# Altium schematic sheet GroveMIDIInTRS.SchDoc
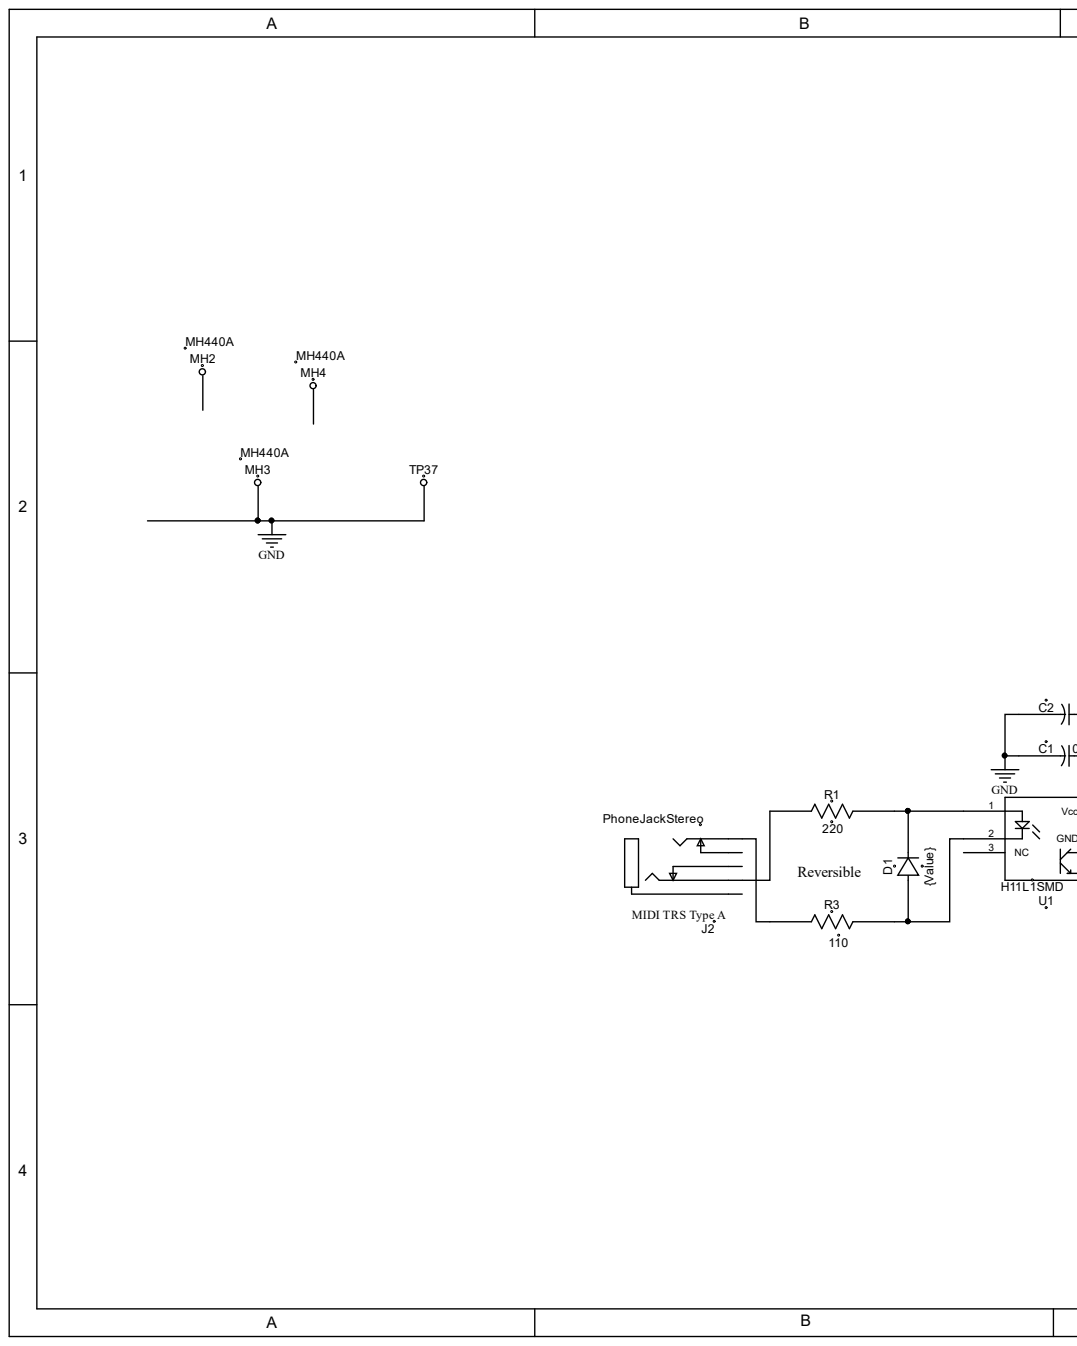
[diagram p0: GroveMIDIInTRS.SchDoc, left]
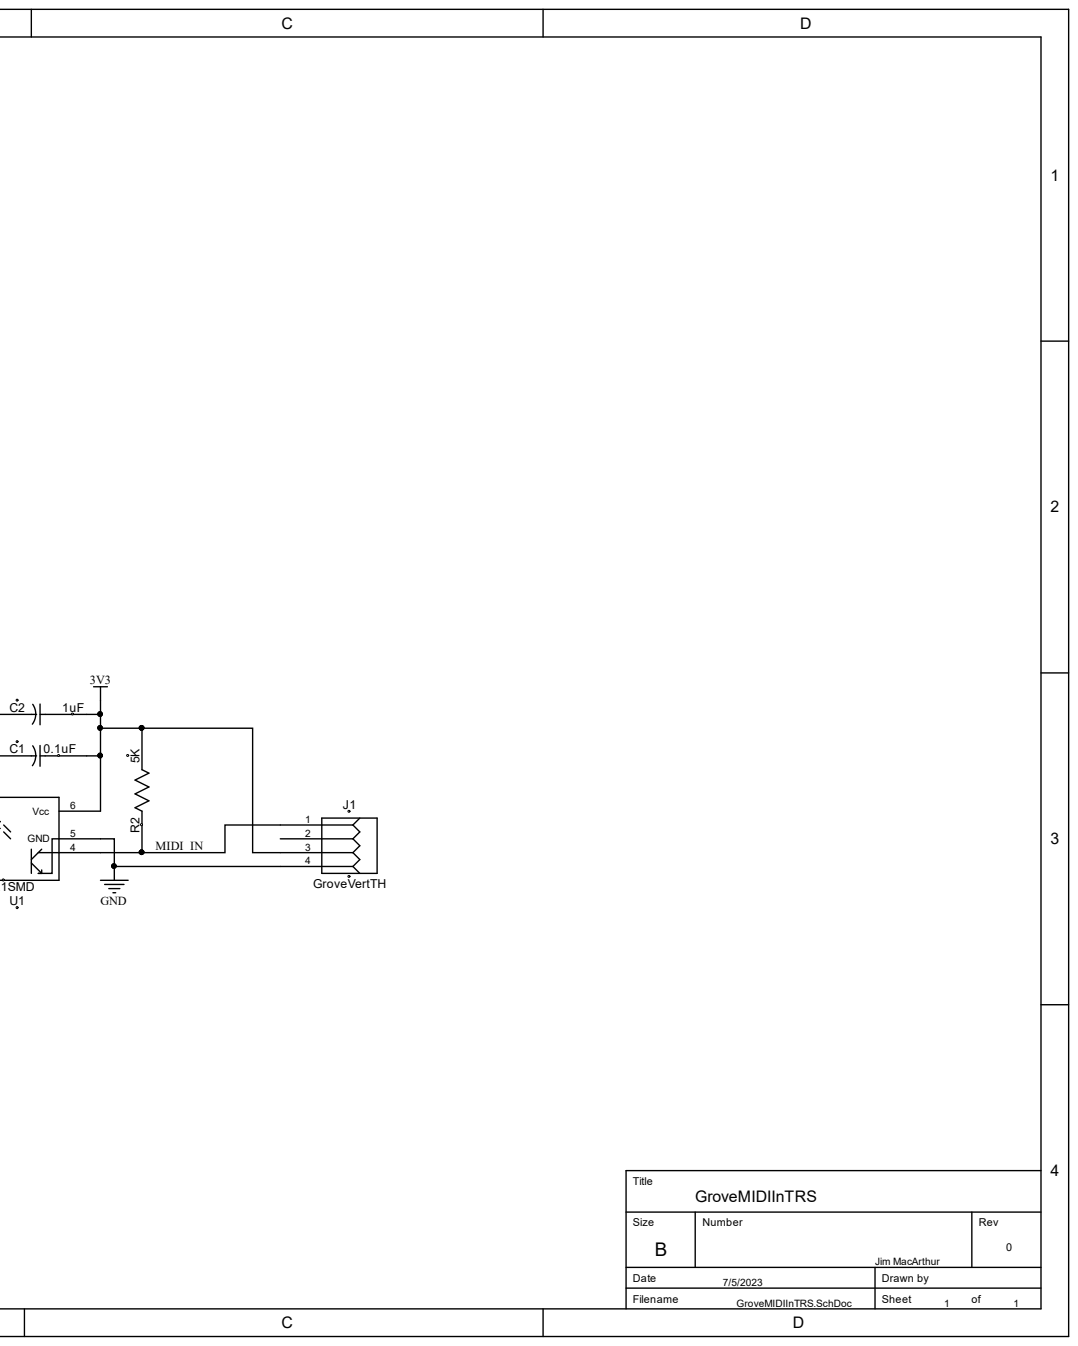
[diagram p1: GroveMIDIInTRS.SchDoc, right]

## Parts
C1  value 0.1uF  [RC0603]  (hidden comment: CAP0603)
C2  value 1uF  [RC0805_CAP0805(Primary)]  (hidden comment: CAP0805)
D1  value {Value}  [DIODE0805_DIODE0805(Primary)]  (hidden comment: DIODE0805)
J1  GroveVertTH  [GroveVertTH]
J2  PhoneJackStereo  [PhoneJackStereo]
MH2  MH440A  [MH440A]
MH3  MH440A  [MH440A]
MH4  MH440A  [MH440A]
R1  value 220  [RC0805_RES0805(Primary)]  (hidden comment: RES0805)
R2  value 5K  [RC0805_RES0805(Primary)]  (hidden comment: RES0805)
R3  value 110  [RC0805_RES0805(Primary)]  (hidden comment: RES0805)
TP37  TP52  [TP52]  (value not drawn)
U1  H11L1SMD  [SO6-Opto]

## Nets
3V3: C1.2 C2.A J1.3 R2.A U1.6(Vcc)
GND: C1.1 C2.B J1.4 MH3.1 TP37.1 U1.5(GND)
MIDI_IN: J1.1 R2.B U1.4
NetD1_A: D1.A R1.A U1.1
NetD1_B: D1.B R3.A U1.2
NetJ2_2: J2.2 R3.B
NetJ2_3: J2.3 R1.B

Not connected: J1 2; J2 1 4 5; MH2 1; MH4 1; U1 3(NC)

## Library data of the parts
J1 = GroveVertTH: vertical thru-hole Grove connector; Component Kind: Standard; Library Name: JMAC.IntLib
J2 = PhoneJackStereo: SJ1-3535; Value: {Value}; Component Kind: Standard; Library Name: JMAC.IntLib
MH2-MH4 = MH440A: Value: {Value}; Component Kind: Standard; Description: MH440A; Library Name: JMAC.IntLib
U1 = H11L1SMD: opto for MIDI; Component Kind: Standard; Library Name: JMAC.IntLib
C1 = CAP0603: Component Kind: Standard; Description: CAP0603; Library Name: JMAC.IntLib
C2 = CAP0805: Component Kind: Standard; Description: CAP0805; Library Name: JMAC.IntLib
D1 = DIODE0805: Component Kind: Standard; Description: DIODE0805; Library Name: JMAC.IntLib
R1-R3 = RES0805: Component Kind: Standard; Description: RES0805; Library Name: JMAC.IntLib
TP37 = TP52: Component Kind: Standard; Description: TP52; Library Name: JMAC.IntLib
